# Altium schematic sheet PSU-1.SchDoc
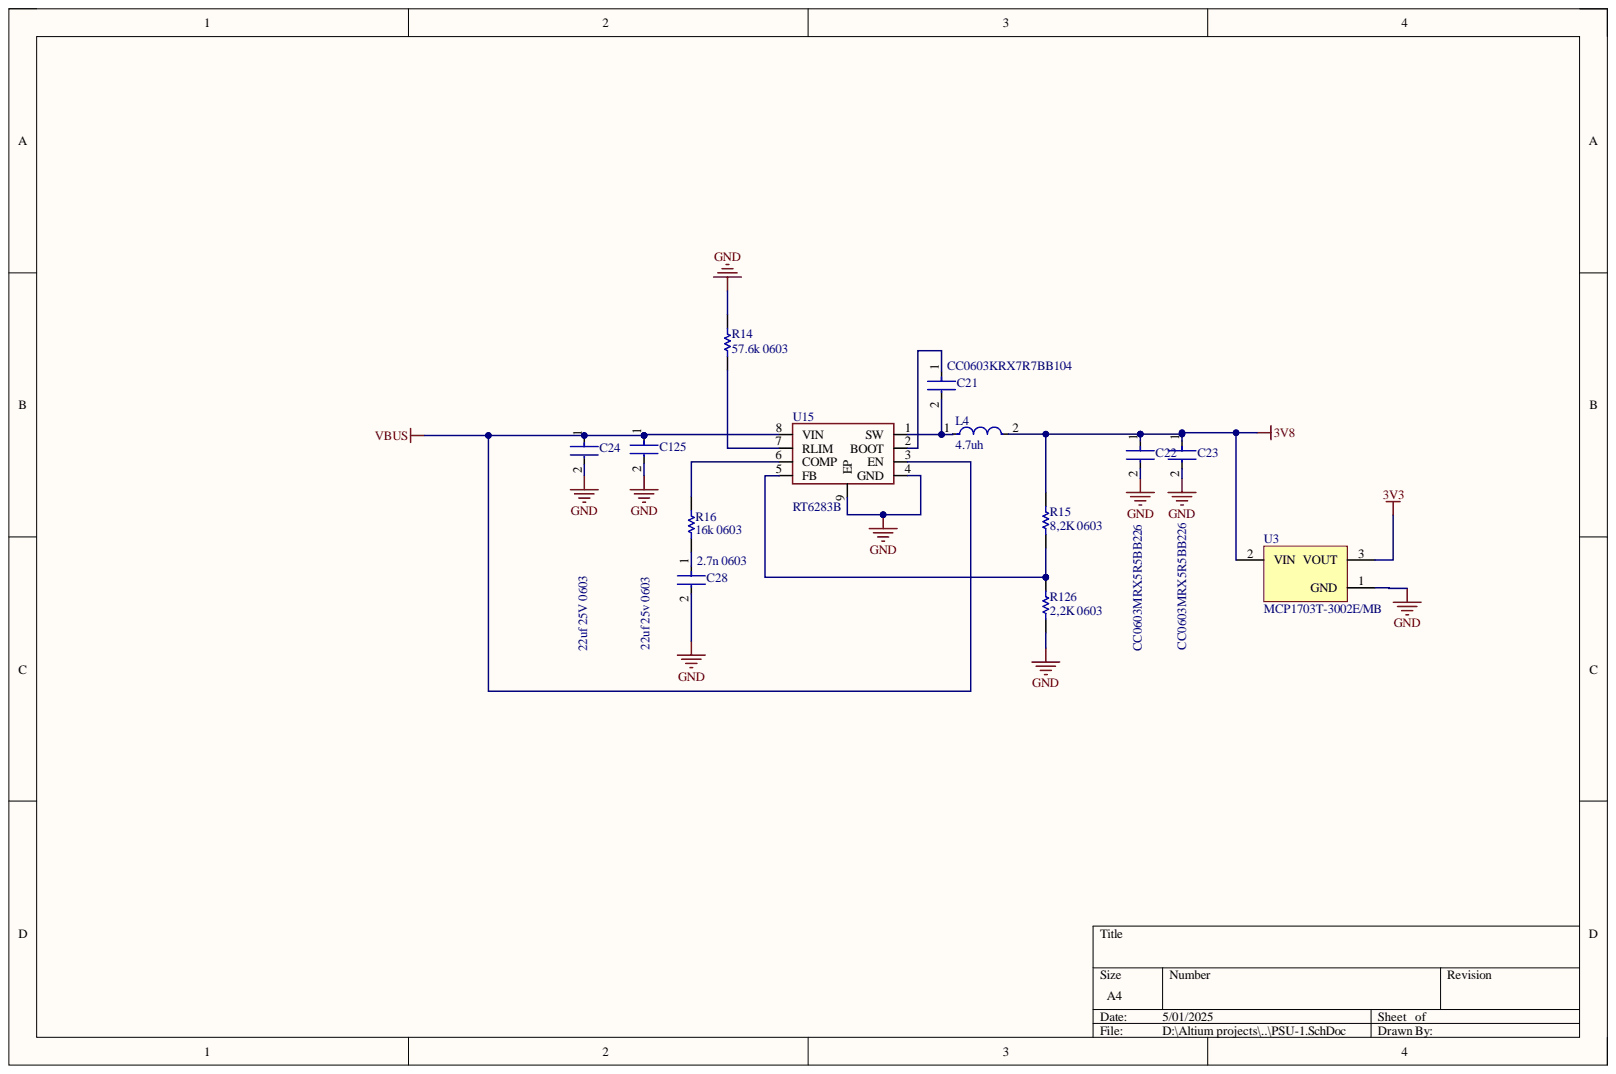
[diagram p0: PSU-1.SchDoc]

## Parts
C21  CC0603KRX7R7BB104  [0603]
C22  CC0603MRX5R5BB226  [0603]
C23  CC0603MRX5R5BB226  [0603]
C24  22uf 25V 0603  [0603]
C28  2.7n 0603  [0603]
C125  22uf 25v 0603  [0603]
L4  4.7uh  [FP-ASPI-0630LR-MFG]
R14  57.6k 0603  [0603]
R15  8,2K 0603  [0603]
R16  16k 0603  [0603]
R126  2,2K 0603  [0603]
U3  MCP1703T-3002E/MB  [SOT-89-MB3_L]
U15  RT6283B  [SOP8]

## Nets
3V3: U3.3
3V8: C22.1 C23.1 L4.2 R15.2 U3.2
GND: C22.2 C23.2 C24.2 C28.2 C125.2 R14.2 R126.1 U3.1 U15.4(GND) U15.9(EP)
VBUS: C24.1 C125.1 U15.3(EN) U15.8(VIN)
NetC21_1: C21.1 U15.2(BOOT)
NetC21_2: C21.2 L4.1 U15.1(SW)
NetC28_1: C28.1 R16.1
NetR14_1: R14.1 U15.7(RLIM)
NetR15_1: R15.1 R126.2 U15.5(FB)
NetR16_2: R16.2 U15.6(COMP)

## Library data of the parts
C21 = 11868f565c42a9108669ba33697ecf6: CAP CER 0.1UF 16V X7R 0603; Manufacturer: YAGEO; Capacitance: 100 nF; Material: Ceramic; Temperature Coefficient: 15%; Tolerance: 0.1; Value: 100 nF; Automotive: No; Category: Cap; Component Kind: Standard; ComponentLink2Description: Datasheet; ComponentLink2URL: http://www.yageo.com/documents/recent/UPY-GPHC_X7R_6.3V-to-50V_18.pdf; Device Class L1: Passive Components; Device Class L2: Capacitors; Device Class L3: Ceramic Capacitors; Digikey Description: CAP CER 0.1UF 16V X7R 0603; Footprint Url: http://www.yageo.com/exep/pages/download/literatures/UPY-C_GEN_24.pdf; Height: 0.9mm; Lead Free: Yes; Library Name: Schlib1.SchLib; Manufacturer Part Number: CC0603KRX7R7BB104; Mouser Description: Multilayer Ceramic Capacitor, CC Series, 0.1 - F, - 10%, X7R, 16 V, 0603 [1608 Metric]; Octopart: https://octopart.com/cc0603krx7r7bb104-yageo-192941; Package: CC0603-DA; RoHS: TRUE; Temperature: -55°C to +125°C; Temperature Characteristic: X7R; Temperature Range High: +125°C; Temperature Range Low: -55°C; Voltage: 16 V; Voltage Rating: 16 V
C22 C23 = b4654b650e69147ec39a6b967a45e02: CAP CER 22UF 6.3V X5R 0603; Tolerance: 20%; Capacitance: 22uF; Case Code (Imperial): 0603; Case Code (Metric): 1608; Case/Package: 0603; Component Kind: Standard; Depth: 0.8mm; Dielectric: X5R; Halogen Free: Halogen Free; Lead Free: Lead Free; Length: 0.063inch; Library Name: Schlib1.SchLib; Max Operating Temperature: 85°C; Min Operating Temperature: -55°C; Mount: Surface Mount; Package Quantity: 4000; Packaging: Tape and Reel; Resistor Type: Ceramic; RoHS Compliant: Yes; Termination: SMD/SMT; Thickness: 0.035inch; Voltage Rating: 6.3V; Voltage Rating (DC): 6.3V; Weight: 7.1E-05oz; Width: 0.031inch
C24 C125 = b4654b650e69147ec39a6b967a45e02: CAP CER 22UF 25V X5R 0603; Tolerance: 20%; Voltage Rating: 6.3V; Capacitance: 22uF; Case Code (Imperial): 0603; Case Code (Metric): 1608; Case/Package: 0603; Component Kind: Standard; Depth: 0.8mm; Dielectric: X5R; Halogen Free: Halogen Free; Lead Free: Lead Free; Length: 0.063inch; Library Name: Schlib1.SchLib; Max Operating Temperature: 85°C; Min Operating Temperature: -55°C; Mount: Surface Mount; Package Quantity: 4000; Packaging: Tape and Reel; Resistor Type: Ceramic; RoHS Compliant: Yes; Termination: SMD/SMT; Thickness: 0.035inch; Voltage Rating (DC): 6.3V; Weight: 7.1E-05oz; Width: 0.031inch
C28 = 11868f565c42a9108669ba33697ecf6: 2.7nf 0603; Manufacturer: YAGEO; Manufacturer Part Number: CC0603KRX7R7BB104; Capacitance: 100 nF; Material: Ceramic; Temperature Characteristic: X7R; Temperature Coefficient: 15%; Tolerance: 0.1; Value: 100 nF; Voltage: 16 V; Automotive: No; Category: Cap; Component Kind: Standard; ComponentLink2Description: Datasheet; ComponentLink2URL: http://www.yageo.com/documents/recent/UPY-GPHC_X7R_6.3V-to-50V_18.pdf; Device Class L1: Passive Components; Device Class L2: Capacitors; Device Class L3: Ceramic Capacitors; Digikey Description: CAP CER 0.1UF 16V X7R 0603; Footprint Url: http://www.yageo.com/exep/pages/download/literatures/UPY-C_GEN_24.pdf; Height: 0.9mm; Lead Free: Yes; Library Name: Schlib1.SchLib; Mouser Description: Multilayer Ceramic Capacitor, CC Series, 0.1 - F, - 10%, X7R, 16 V, 0603 [1608 Metric]; Octopart: https://octopart.com/cc0603krx7r7bb104-yageo-192941; Package: CC0603-DA; RoHS: TRUE; Temperature: -55°C to +125°C; Temperature Range High: +125°C; Temperature Range Low: -55°C; Voltage Rating: 16 V
L4 = CMP-27750-000262-1: FIXED IND 4.7UH 4A 68 MOHM SMD; Core Material: Carbonyl Powder; DC Resistance (DCR): 68mR; Inductance: 10uH; Max DC Current: 5.5A; Shielding: Unshielded; Test Frequency: 100kHz; Tolerance: 20%; Case/Package: Nonstandard; Component Kind: Standard; Current Rating: 4A; Depth: 6.65mm; Height: 3mm; Height - Seated (Max): 0.118inch; Lead Free: Lead Free; Length: 0.283inch; Library Name: Altium Content Vault; Max Operating Temperature: 125°C; Min Operating Temperature: -55°C; Mount: Surface Mount; Packaging: Tape and Reel; Radiation Hardening: No; RoHS Compliant: Yes; Saturation Current: 5.5A; Series: ASPI-0630LR; Termination: SMD/SMT; Width: 0.262inch
R14 R16 R126 = RES-2: Capacitance: 1 mF; Case\Package: Radial; Tolerance: 20%; Voltage Rating: 25 V; Component Kind: Standard; ComponentLink1Description: Manufacturer URL; ComponentLink1URL: http://www.yageo.com/; ComponentLink2Description: Datasheet; ComponentLink2URL: http://www.yageo.com/documents/recent/PYu-RC_Group_51_RoHS_L_04.pdf; DatasheetVersion: Rev. 4, 02/2016; Diameter: 10 mm; Height: 20 mm; Lead Pitch: 5 mm; Length: 20 mm; Library Name: Schlib1.SchLib; Max Operating Temperature: 85 degC; Min Operating Temperature: -40 degC; Mount: Through Hole; Package Quantity: 200; PackageDescription: 2-Pin Surface Mount Device, Body 1.6 x 0.8 mm; PackageReference: 0603; Packaging: Bulk; Radiation Hardening: No; REACH SVHC: No SVHC; RoHSCompliant: true; Voltage Rating (DC): 25 V; Width: 0 inch
  R14: Description: 57.6k 0603
  R16: Description: 16K 0603
  R126: Description: 2,2K 0603
R15 = RES-2: 8,2K; Capacitance: 1 mF; Case\Package: Radial; Tolerance: 20%; Voltage Rating: 25 V; Component Kind: Standard; ComponentLink1Description: Manufacturer URL; ComponentLink1URL: http://www.yageo.com/; ComponentLink2Description: Datasheet; ComponentLink2URL: http://www.yageo.com/documents/recent/PYu-RC_Group_51_RoHS_L_04.pdf; DatasheetVersion: Rev. 4, 02/2016; Diameter: 10 mm; Height: 20 mm; Lead Pitch: 5 mm; Length: 20 mm; Library Name: Schlib1.SchLib; Max Operating Temperature: 85 degC; Min Operating Temperature: -40 degC; Mount: Through Hole; Package Quantity: 200; PackageDescription: 2-Pin Surface Mount Device, Body 1.6 x 0.8 mm; PackageReference: 0603; Packaging: Bulk; Radiation Hardening: No; REACH SVHC: No SVHC; RoHSCompliant: true; Voltage Rating (DC): 25 V; Width: 0 inch
U3 = CMP-0136-00850-1: 250 mA, 16V, Low Quiescent Current LDO Regulator, 3-Pin SOT-89, Extended Temperature, Tape and Reel; Code_IPC: SOT89; Component Kind: Standard; DatasheetVersion: revD, Sep-2009; Library Name: Altium Content Vault; PackageDescription: 3-Lead Plastic Small Outline Transistor Header (MB) [SOT-89]; PackageReference: SOT-89-MB3; PackageVersion: revBB, Aug-2009
U15 = RT6283B: Component Kind: Standard; Library Name: Schlib1.SchLib
